# Altium schematic sheet Airlock_Power.SchDoc(Airlock_Power)
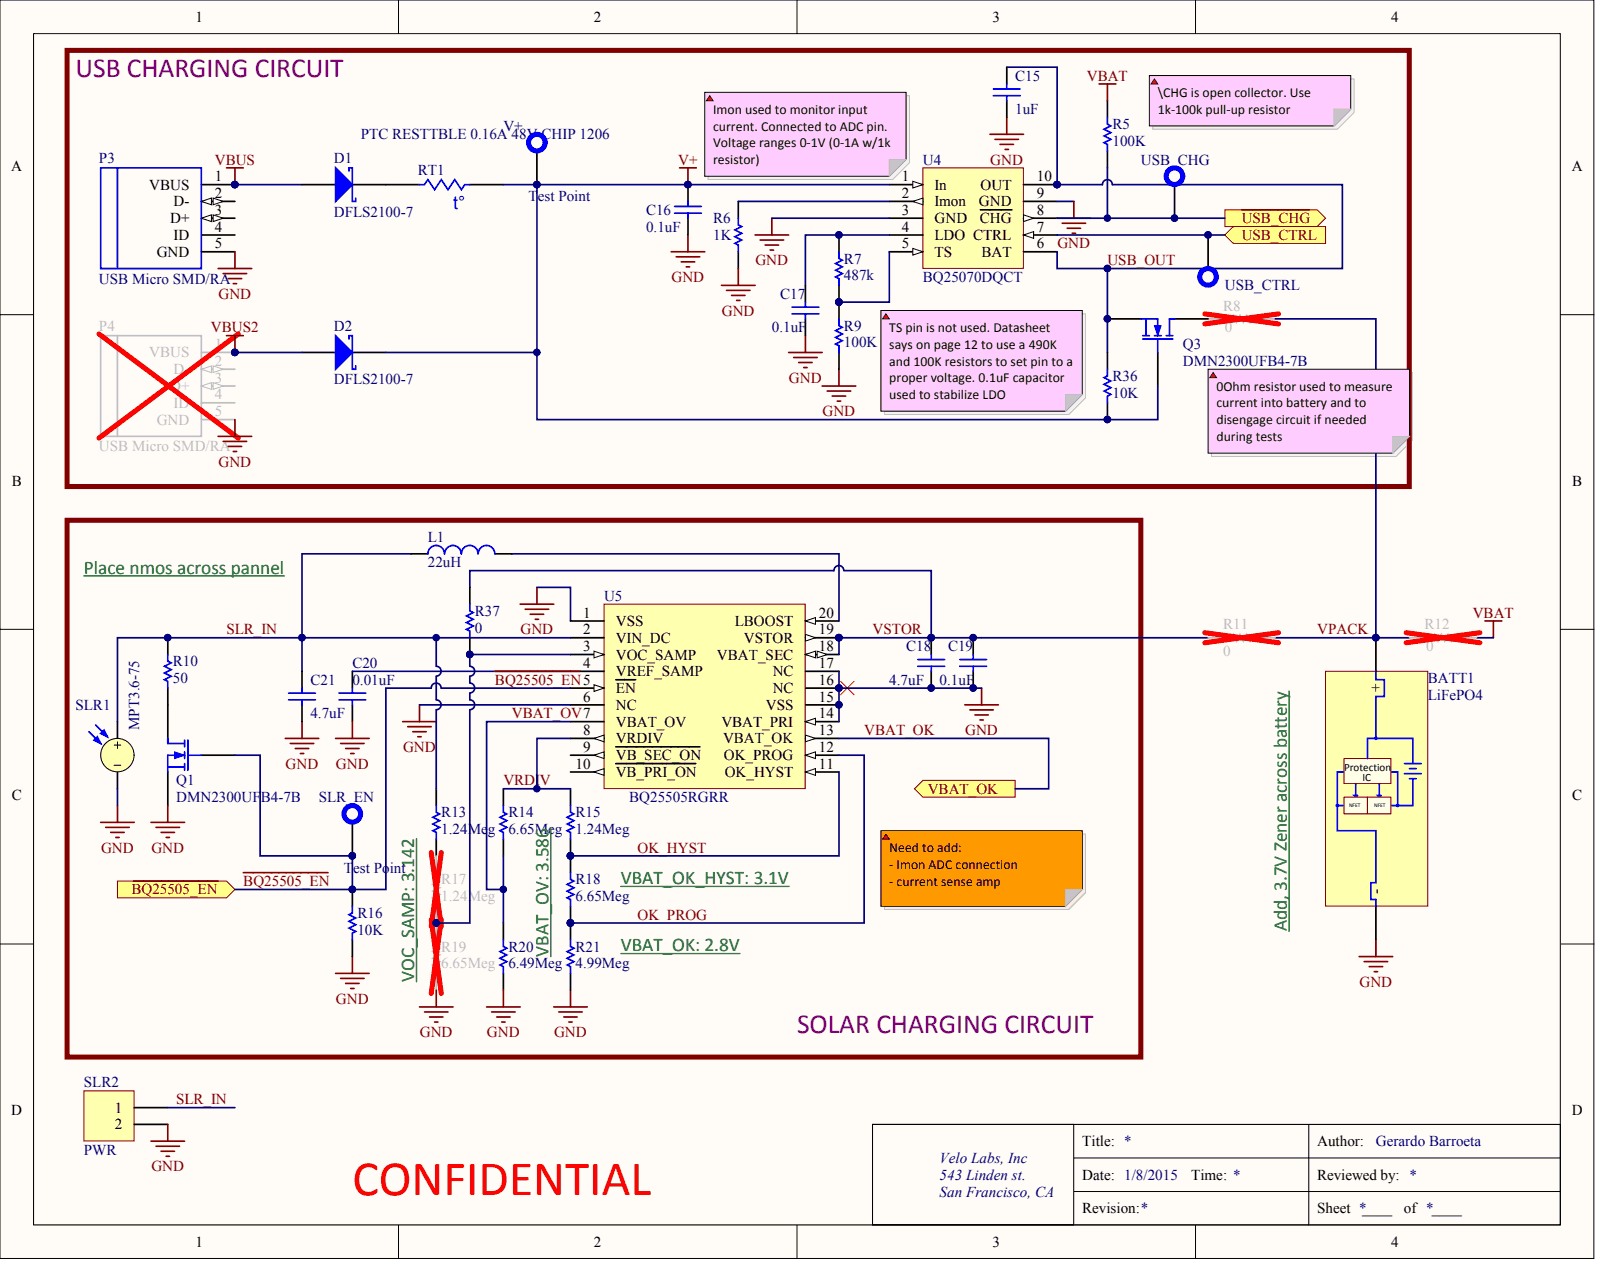
[diagram p0: Airlock_Power.SchDoc]

## Parts
BATT1  LiFePO4
C15  value 1uF  (hidden comment: X5R, 6.3V 10%)
C16  value 0.1uF  (hidden comment: X7R, 16V, 10%)
C17  value 0.1uF  (hidden comment: X7R, 16V, 10%)
C18  value 4.7uF  (hidden comment: X5R, 10V, 10%)
C19  value 0.1uF  (hidden comment: X7R, 16V, 10%)
C20  value 0.01uF  (hidden comment: X7R, 25V, 10%)
C21  value 4.7uF  (hidden comment: X5R, 10V, 10%)
D1  DFLS2100-7
D2  DFLS2100-7
L1  value 22uH  (hidden comment: Inductor)
P3  USB Micro SMD/RA
P4  USB Micro SMD/RA
Q1  DMN2300UFB4-7B
Q3  DMN2300UFB4-7B
R5  value 100K  (hidden comment: 5% 1/10W)
R6  1%  value 1K
R7  value 487k  (hidden comment: 1%)
R8  value 0  (hidden comment: Res1)
R9  value 100K  (hidden comment: 5% 1/10W)
R10  value 50  (hidden comment: 1%)
R11  value 0  (hidden comment: Res1)
R12  value 0  (hidden comment: Res1)
R13  1%  value 1.24Meg
R14  value 6.65Meg  (hidden comment: Res1)
R15  1%  value 1.24Meg
R16  1%  value 10K
R17  1%  value 1.24Meg
R18  value 6.65Meg  (hidden comment: Res1)
R19  value 6.65Meg  (hidden comment: Res1)
R20  value 6.49Meg  (hidden comment: 1%)
R21  value 4.99Meg  (hidden comment: 1%)
R36  1%  value 10K
R37  value 0  (hidden comment: Res1)
RT1  PTC RESTTBLE 0.16A 48V CHIP 1206
SLR1  MPT3.6-75
SLR2  PWR
SLR_EN  Test Point
U4  BQ25070DQCT
U5  BQ25505RGRR
USB_CHG  Test Point
USB_CTRL  Test Point
V+  Test Point

## Ports
USB_CTRL, VBAT_OK, ~{BQ25505_EN}, ~{USB_CHG}

## Nets
GND: BATT1.2 C15.1 C16.1 C17.1 C18.1 C19.1 C20.1 C21.1 P3.0 P3.5(GND) P4.0 P4.5(GND) Q1.2 R6.1 R9.2 R16.2 R19.1 R20.1 R21.1 SLR1.2 SLR2.2 U4.3(GND) U4.9(GND) U4.11 U5.1(VSS) U5.6(NC) U5.14(VBAT_PRI) U5.15(VSS) U5.16(NC) U5.17(NC) U5.21
V+: C16.2 D2.1 Q3.1 R36.2 RT1.1 U4.1(In) V+.1
VBAT: R5.2 R12.1
VBUS: D1.2 P3.1(VBUS)
VBUS2: D2.2 P4.1(VBUS)
~{BQ25505_EN}: Q1.1 R16.1 SLR_EN.1 U5.5(EN) ; port ~{BQ25505_EN}
~{USB_CHG}: R5.1 U4.8(CHG) USB_CHG.1 ; port ~{USB_CHG}
OK_HYST: R15.1 R18.2 U5.11(OK_HYST)
OK_PROG: R18.1 R21.2 U5.12(OK_PROG)
SLR_IN: C21.2 L1.1 R10.1 R13.2 SLR1.1 SLR2.1 U5.2(VIN_DC)
USB_CTRL: U4.7(CTRL) USB_CTRL.1 ; port USB_CTRL
USB_OUT: C15.2 Q3.2 R36.1 U4.6(BAT) U4.10(OUT)
VBAT_OK: U5.13(VBAT_OK) ; port VBAT_OK
VBAT_OV: R14.1 R20.2 U5.7(VBAT_OV)
VPACK: BATT1.1 R8.1 R11.2 R12.2
VRDIV: R14.2 R15.2 U5.8(VRDIV)
VSTOR: C18.2 C19.2 R11.1 R37.2 U5.18(VBAT_SEC) U5.19(VSTOR)
NetC17_2: C17.2 R7.1 U4.4(LDO)
NetC20_2: C20.2 U5.4(VREF_SAMP)
NetD1_1: D1.1 RT1.2
NetL1_2: L1.2 U5.20(LBOOST)
NetQ1_3: Q1.3 R10.2
NetQ3_3: Q3.3 R8.2
NetR6_2: R6.2 U4.2(Imon)
NetR7_2: R7.2 R9.1 U4.5(TS)
NetR13_1: R13.1 R17.2
NetR17_1: R17.1 R19.2 R37.1 U5.3(VOC_SAMP)

Not connected: P3 2(D-) 3(D+) 4(ID); P4 2(D-) 3(D+) 4(ID); U5 9(VB_SEC_ON) 10(VB_PRI_ON)

## Library data of the parts
C15 = (no symbol name): Manufacturer: Murata Electronics North America; Manufacturer Part Number: GRM155R60J105KE19D; Description 1: CAP CER 1UF 6.3V 10% X5R 0402; Capacitance: 1.0µF; Applications: General Purpose; Supplier 1: Digi-Key; Supplier Part Number 1: 490-1320-1-ND; RoHS: RoHS Compliant; Category: Capacitors; Stock 1: 2947181; Pricing 1: 1=0.1, 10=0.035, 50=0.0192, 100=0.0163, 250=0.01332, 500=0.01138, 1000=0.0087, 5000=0.00725 (USD); Packaging: Cut Tape (CT); Tolerance: ±10%; Voltage - Rated: 6.3V; Temperature Coefficient: X5R; Mounting Type: Surface Mount, MLCC; Operating Temperature: -55°C ~ 85°C; Ratings: -; Package / Case: 0402 (1005 Metric); Size / Dimension: 0.039" L x 0.020" W (1.00mm x 0.50mm); Height - Seated (Max): -; Thickness (Max): 0.022" (0.55mm); Lead Spacing: -; Features: -; Lead Style: -; ComponentLink1URL: http://www.murata.com/~/media/webrenewal/support/library/catalog/products/capacitor/mlcc/c02e.ashx; ComponentLink1Description: http://www.murata.com/~/media/webrenewal/support/library/catalog/products/capacitor/mlcc/c02e.ashx
C16 C17 C19 = (no symbol name): Manufacturer: Murata Electronics North America; Manufacturer Part Number: GRM155R71C104KA88D; Description 1: CAP CER 0.1UF 16V 10% X7R 0402; Capacitance: 0.1µF; Supplier 1: Digi-Key; Supplier Part Number 1: 490-3261-1-ND; RoHS: RoHS Compliant; Category: Capacitors; Stock 1: 16410340; Pricing 1: 1=0.1, 10=0.015, 50=0.0082, 100=0.0069, 250=0.00564, 500=0.00482, 1000=0.00375, 5000=0.00313 (USD); Packaging: Cut Tape (CT); Tolerance: ±10%; Voltage - Rated: 16V; Temperature Coefficient: X7R; Mounting Type: Surface Mount, MLCC; Operating Temperature: -55°C ~ 125°C; Applications: General Purpose; Ratings: -; Package / Case: 0402 (1005 Metric); Size / Dimension: 0.039" L x 0.020" W (1.00mm x 0.50mm); Height - Seated (Max): -; Thickness (Max): 0.022" (0.55mm); Lead Spacing: -; Features: -; Lead Style: -; ComponentLink1URL: http://www.murata.com/~/media/webrenewal/support/library/catalog/products/capacitor/mlcc/c02e.ashx; ComponentLink1Description: http://www.murata.com/~/media/webrenewal/support/library/catalog/products/capacitor/mlcc/c02e.ashx
C18 C21 = (no symbol name): Manufacturer: Taiyo Yuden; Manufacturer Part Number: LMK107BJ475KA-T; Description 1: CAP CER 4.7UF 10V 10% X5R 0603; Capacitance: 4.7µF; Supplier 1: Digi-Key; Supplier Part Number 1: 587-1441-2-ND; RoHS: RoHS Compliant; Category: Capacitors; Stock 1: 360000; Pricing 1: 4000=0.038, 8000=0.0361, 12000=0.0342, 28000=0.0323 (USD); Packaging: Tape & Reel (TR); Voltage - Rated: 10V; Tolerance: ±10%; Temperature Coefficient: X5R; Mounting Type: Surface Mount, MLCC; Operating Temperature: -55°C ~ 85°C; Applications: General Purpose; Ratings: -; Package / Case: 0603 (1608 Metric); Size / Dimension: 0.063" L x 0.031" W (1.60mm x 0.80mm); Height - Seated (Max): -; Thickness (Max): 0.035" (0.90mm); Lead Spacing: -; Features: -; Lead Style: -; ComponentLink1URL: http://www.yuden.co.jp/or/product/category/capacitor/LMK107BJ475KA-T.pdf; ComponentLink1Description: http://www.yuden.co.jp/or/product/category/capacitor/LMK107BJ475KA-T.pdf
C20 = (no symbol name): Manufacturer: Murata Electronics North America; Manufacturer Part Number: GRM155R71E103KA01D; Description 1: CAP CER 10000PF 25V 10% X7R 0402; Capacitance: 10000pF; Published: 8-Jun-2000; LatestRevisionDate: 29-May-2009; LatestRevisionNote: IPC-7351 Footprint Added.; PackageReference: C1206; PackageDescription: Chip Capacitor; Supplier 1: Digi-Key; Supplier Part Number 1: 490-1312-1-ND; RoHS: RoHS Compliant; Category: Capacitors; Stock 1: 1062157; Pricing 1: 1=0.1, 10=0.014, 50=0.0078, 100=0.0066, 250=0.0054, 500=0.00462, 1000=0.0036, 5000=0.003 (USD); Packaging: Cut Tape (CT); Voltage - Rated: 25V; Tolerance: ±10%; Temperature Coefficient: X7R; Mounting Type: Surface Mount, MLCC; Operating Temperature: -55°C ~ 125°C; Applications: General Purpose; Ratings: -; Package / Case: 0402 (1005 Metric); Size / Dimension: 0.039" L x 0.020" W (1.00mm x 0.50mm); Height - Seated (Max): -; Thickness (Max): 0.022" (0.55mm); Lead Spacing: -; Features: -; Lead Style: -; ComponentLink1URL: http://www.murata.com/products/catalog/pdf/c02e.pdf; ComponentLink1Description: http://www.murata.com/products/catalog/pdf/c02e.pdf; Publisher: Altium Limited
D1 D2 = (no symbol name): Manufacturer: Diodes Incorporated; Description 1: DIODE SCHOTKY 100V 2A POWERDI123; Diode Type: Schottky; Voltage - DC Reverse (Vr) (Max): 100V; Current - Average Rectified (Io): 2A; Voltage - Forward (Vf) (Max) @ If: 860mV @ 2A; Speed: Fast Recovery =< 500ns, > 200mA (Io); Current - Reverse Leakage @ Vr: 1µA @ 100V; Capacitance @ Vr, F: 36pF @ 5V, 1MHz; Supplier 1: Digi-Key; Manufacturer Part Number: DFLS2100-7; RoHS: RoHS Compliant; Category: Discrete Semiconductor Products; Stock 1: 21950; Pricing 1: 1=0.74, 10=0.65, 25=0.5744, 100=0.5004, 250=0.4356, 500=0.3708, 1000=0.297 (USD); Packaging: Cut Tape (CT); Reverse Recovery Time (trr): -; Mounting Type: Surface Mount; Package / Case: 2-SMD, Flat Lead; Supplier Device Package: PowerDI™ 123; Operating Temperature - Junction: -55°C ~ 175°C; ComponentLink1URL: http://www.diodes.com/datasheets/ds31475.pdf; ComponentLink1Description: http://www.diodes.com/datasheets/ds31475.pdf; Supplier Part Number 1: DFLS2100-7DICT-ND
L1 = (no symbol name): Manufacturer: Coilcraft; Manufacturer Part Number: LPS4018-223MRC; Code_IEC: 1005; Description 1: Fixed Inductors 22 uH 0.9 A 20% SMD Shielded; Core Material: Ferrite; Inductance: 22 uH; Maximum DC Current: 830 mA; Maximum DC Resistance: 360 mOhms; Self Resonant Frequency: 26 MHz; Shielding: Shielded; Tolerance: 20 %; Type: Shielded Power Inductor; Case Height: 1.7 mm; Case Length: 4 mm; Test Frequency: 100 kHz; Product Status: New Product; Published: 8-Jun-2000; LatestRevisionDate: 29-May-2009; LatestRevisionNote: IPC-7351 Footprint Added.; PackageReference: 0402-A; PackageDescription: Chip Inductor; Code_JEITA: 0402; Supplier 1: Mouser; Supplier Part Number 1: 994-LPS4018-223MRC; RoHS: RoHS Compliant; Category: Fixed Inductors; Stock 1: 7000; Pricing 1: 1000=0.684, 2000=0.637 (AUD); Operating Temperature Range: - 40 C to + 85 C; Packaging: Reel; Product: Power Inductors; Series: LPS4018; Termination Style: SMD/SMT; Brand: Coilcraft; Case Width: 4 mm; RoHS - Mouser: Y; Minimum Order Quantity: 1000; Reeling: True; ComponentLink1URL: http://www.coilcraft.com/lps4018.cfm; ComponentLink1Description: http://www.coilcraft.com/lps4018.cfm; Publisher: Altium Limited
P3 P4 = (no symbol name): Manufacturer: FCI; Manufacturer Part Number: 10104111-0001LF; Description 1: CONN RCPT STD MICRO USB TYPE AB; Connector Type: USB - micro AB; Number of Contacts: 5; Gender: Receptacle; Specifications: USB 2.0; Supplier 1: Digi-Key; RoHS: RoHS Compliant; Category: Connectors, Interconnects; Stock 1: 13009; Pricing 1: 1=0.79, 10=0.742, 25=0.6676, 50=0.5934, 100=0.5687, 250=0.51928, 500=0.49456 (USD); Packaging: Cut Tape (CT); Mounting Type: Surface Mount, Right Angle, Horizontal; Features: -; Ingress Protection: -; ComponentLink1URL: http://portal.fciconnect.com/Comergent//fci/drawing/10104111.pdf; ComponentLink1Description: http://portal.fciconnect.com/Comergent//fci/drawing/10104111.pdf; Supplier Part Number 1: 609-4053-1-ND
Q1 Q3 = (no symbol name): Manufacturer: Diodes Inc; Description 1: MOSF N CH 20V 1.3A DFN1006H4-3; FET Type: MOSFET N-Channel, Metal Oxide; FET Feature: Logic Level Gate; Drain to Source Voltage (Vdss): 20V; Current - Continuous Drain (Id) @ 25° C: 1.3A (Ta); Rds On (Max) @ Id, Vgs: 175 mOhm @ 300mA, 4.5V; Vgs(th) (Max) @ Id: 950mV @ 250µA; Gate Charge (Qg) @ Vgs: 1.6nC @ 4.5V; Input Capacitance (Ciss) @ Vds: 64.3pF @ 25V; Power - Max: 470mW; Supplier 1: Digi-Key; Manufacturer Part Number: DMN2300UFB4-7B; RoHS: RoHS Compliant; Category: Discrete Semiconductor Products; Stock 1: 61054; Pricing 1: 1=0.48, 10=0.348, 25=0.2704, 100=0.2048, 250=0.14476, 500=0.11588, 1000=0.08888, 2500=0.07725, 5000=0.06938 (USD); Packaging: Digi-Reel®; Mounting Type: Surface Mount; Package / Case: 3-XFDFN; Supplier Device Package: 3-DFN1006H4 (1.0x0.6); ComponentLink1URL: http://www.diodes.com/datasheets/DMN2300UFB4.pdf; ComponentLink1Description: http://www.diodes.com/datasheets/DMN2300UFB4.pdf; Supplier Part Number 1: DMN2300UFB4-7BDIDKR-ND
R5 R9 = (no symbol name): Manufacturer: Panasonic Electronic Components; Manufacturer Part Number: ERJ-2GEJ104X; Description 1: RES 100K OHM 1/10W 5% 0402 SMD; Power (Watts): 0.1W, 1/10W; Temperature Coefficient: ±200ppm/°C; Published: 8-Jun-2000; LatestRevisionDate: 17-Jul-2002; LatestRevisionNote: Re-released for DXP Platform.; PackageReference: AXIAL-0.3; PackageDescription: Axial Device, Thru-Hole; 2 Leads; 0.3 in Pin Spacing; Supplier 1: Digi-Key; Supplier Part Number 1: P100KJCT-ND; RoHS: RoHS Compliant; Category: Resistors; Stock 1: 539799; Pricing 1: 1=0.1, 50=0.0172, 100=0.0131, 250=0.00976, 500=0.0072, 1000=0.0048, 5000=0.00338 (USD); Packaging: Cut Tape (CT); Resistance (Ohms): 100k; Tolerance: ±5%; Composition: Thick Film; Features: -; Package / Case: 0402 (1005 Metric); Supplier Device Package: 0402; Size / Dimension: 0.039" L x 0.020" W (1.00mm x 0.50mm); Height: 0.016" (0.40mm); Number of Terminations: 2; ComponentLink1URL: http://industrial.panasonic.com/www-cgi/jvcr13pz.cgi?E+PZ+3+AOA0001+ERJ2GEJ104X+7+WW; ComponentLink1Description: http://industrial.panasonic.com/www-cgi/jvcr13pz.cgi?E+PZ+3+AOA0001+ERJ2GEJ104X+7+WW; Publisher: Altium Limited
R6 = (no symbol name): Manufacturer: Panasonic Electronic Components; Manufacturer Part Number: ERJ-2RKF1001X; Description 1: RES 1K OHM 1/10W 1% 0402 SMD; Power (Watts): 0.1W, 1/10W; Temperature Coefficient: ±100ppm/°C; Published: 8-Jun-2000; LatestRevisionDate: 17-Jul-2002; LatestRevisionNote: Re-released for DXP Platform.; PackageReference: AXIAL-0.3; PackageDescription: Axial Device, Thru-Hole; 2 Leads; 0.3 in Pin Spacing; Supplier 1: Digi-Key; Supplier Part Number 1: P1.00KLCT-ND; RoHS: RoHS Compliant; Category: Resistors; Stock 1: 5044564; Pricing 1: 1=0.1, 50=0.0196, 100=0.0149, 250=0.01104, 500=0.00816, 1000=0.00544, 5000=0.00383 (USD); Packaging: Cut Tape (CT); Resistance (Ohms): 1k; Tolerance: ±1%; Composition: Thick Film; Features: -; Package / Case: 0402 (1005 Metric); Supplier Device Package: 0402; Size / Dimension: 0.039" L x 0.020" W (1.00mm x 0.50mm); Height: 0.016" (0.40mm); Number of Terminations: 2; ComponentLink1URL: http://industrial.panasonic.com/www-cgi/jvcr13pz.cgi?E+PZ+3+AOA0002+ERJ2RKF1001X+7+WW; ComponentLink1Description: http://industrial.panasonic.com/www-cgi/jvcr13pz.cgi?E+PZ+3+AOA0002+ERJ2RKF1001X+7+WW; Operating Temperature: -55°C ~ 155°C; Publisher: Altium Limited
R7 = (no symbol name): Manufacturer: Panasonic Electronic Components; Manufacturer Part Number: ERJ-2RKF4873X; Description 1: RES 487K OHM 1/10W 1% 0402 SMD; Power (Watts): 0.1W, 1/10W; Temperature Coefficient: ±100ppm/°C; Supplier 1: Digi-Key; Supplier Part Number 1: P487KLCT-ND; RoHS: RoHS Compliant; Category: Resistors; Stock 1: 15470; Pricing 1: 1=0.1, 50=0.0196, 100=0.0149, 250=0.01104, 500=0.00816, 1000=0.00544, 5000=0.00383 (USD); Packaging: Cut Tape (CT); Resistance (Ohms): 487k; Tolerance: ±1%; Composition: Thick Film; Features: -; Package / Case: 0402 (1005 Metric); Supplier Device Package: 0402; Size / Dimension: 0.039" L x 0.020" W (1.00mm x 0.50mm); Height: 0.016" (0.40mm); Number of Terminations: 2; ComponentLink1URL: http://industrial.panasonic.com/www-cgi/jvcr13pz.cgi?E+PZ+3+AOA0002+ERJ2RKF4873X+7+WW; ComponentLink1Description: http://industrial.panasonic.com/www-cgi/jvcr13pz.cgi?E+PZ+3+AOA0002+ERJ2RKF4873X+7+WW
R8 R11 R12 R37 = (no symbol name): Manufacturer: Panasonic Electronic Components; Manufacturer Part Number: ERJ-3GEY0R00V; Description 1: RES SMD 0.0 OHM JUMPER 1/10W; Resistance (Ohms): 0.0; Tolerance: Jumper; Power (Watts): 0.1W, 1/10W; Published: 8-Jun-2000; LatestRevisionDate: 17-Jul-2002; LatestRevisionNote: Re-released for DXP Platform.; PackageReference: AXIAL-0.3; PackageDescription: Axial Device, Thru-Hole; 2 Leads; 0.3 in Pin Spacing; Supplier 1: Digi-Key; Supplier Part Number 1: P0.0GCT-ND; RoHS: RoHS Compliant; Category: Resistors; Stock 1: 6006949; Pricing 1: 1=0.1, 50=0.0126, 100=0.0096, 250=0.00716, 500=0.00528, 1000=0.00352, 2500=0.00297 (USD); Packaging: Cut Tape (CT); Composition: Thick Film; Features: Automotive AEC-Q200 Qualified; Temperature Coefficient: -; Operating Temperature: -55°C ~ 155°C; Package / Case: 0603 (1608 Metric); Supplier Device Package: 0603; Size / Dimension: 0.063" L x 0.031" W (1.60mm x 0.80mm); Height: 0.022" (0.55mm); Number of Terminations: 2; ComponentLink1URL: http://industrial.panasonic.com/www-cgi/jvcr13pz.cgi?E+PZ+3+AOA0001+ERJ3GEY0R00V+7+WW; ComponentLink1Description: http://industrial.panasonic.com/www-cgi/jvcr13pz.cgi?E+PZ+3+AOA0001+ERJ3GEY0R00V+7+WW; Publisher: Altium Limited
R10 = (no symbol name): Manufacturer: Panasonic Electronic Components; Manufacturer Part Number: ERJ-8ENF51R1V; Description 1: RES SMD 51.1 OHM 1% 1/4W 1206; Resistance (Ohms): 51.1; Power (Watts): 0.25W, 1/4W; Temperature Coefficient: ±100ppm/°C; Supplier 1: Digi-Key; Supplier Part Number 1: P51.1FCT-ND; RoHS: RoHS Compliant; Category: Resistors; Stock 1: 62699; Pricing 1: 1=0.1, 50=0.0368, 100=0.028, 250=0.0208, 500=0.01536, 1000=0.01024 (USD); Packaging: Cut Tape (CT); Tolerance: ±1%; Composition: Thick Film; Features: Automotive AEC-Q200 Qualified; Operating Temperature: -55°C ~ 155°C; Package / Case: 1206 (3216 Metric); Supplier Device Package: 1206; Size / Dimension: 0.126" L x 0.063" W (3.20mm x 1.60mm); Height: 0.028" (0.70mm); Number of Terminations: 2; ComponentLink1URL: http://industrial.panasonic.com/www-cgi/jvcr13pz.cgi?E+PZ+3+AOA0002+ERJ8ENF51R1V+7+WW; ComponentLink1Description: http://industrial.panasonic.com/www-cgi/jvcr13pz.cgi?E+PZ+3+AOA0002+ERJ8ENF51R1V+7+WW
R13 R15 R17 = (no symbol name): Manufacturer: Vishay Dale; Manufacturer Part Number: CRCW04021M24FKED; Description 1: RES 1.24M OHM 1/16W 1% 0402 SMD; Resistance (Ohms): 1.24M; Power (Watts): 0.063W, 1/16W; Temperature Coefficient: ±100ppm/°C; Supplier 1: Digi-Key; Supplier Part Number 1: 541-1.24MLDKR-ND; RoHS: RoHS Compliant; Category: Resistors; Stock 1: 8506; Pricing 1: 10=0.083, 50=0.0444, 200=0.0255, 1000=0.0174 (USD); Packaging: Digi-Reel®; Tolerance: ±1%; Composition: Thick Film; Features: Automotive AEC-Q200 Qualified; Package / Case: 0402 (1005 Metric); Supplier Device Package: 0402 (1005 Metric); Size / Dimension: 0.039" L x 0.020" W (1.00mm x 0.50mm); Height: 0.016" (0.40mm); Number of Terminations: 2; ComponentLink1URL: http://www.vishay.com/doc?20035; ComponentLink1Description: http://www.vishay.com/doc?20035
R14 R18 R19 = (no symbol name): Manufacturer: Vishay Dale; Manufacturer Part Number: CRCW06036M65FKEA; Description 1: RES 6.65M OHM 1/10W 1% 0603 SMD; Resistance (Ohms): 6.65M; Tolerance: ±1%; Power (Watts): 0.1W, 1/10W; Temperature Coefficient: ±100ppm/°C; Supplier 1: Digi-Key; Supplier Part Number 1: 541-6.65MHDKR-ND; RoHS: RoHS Compliant; Category: Resistors; Stock 1: 15342; Pricing 1: 10=0.081, 50=0.0436, 200=0.0251, 1000=0.01711 (USD); Packaging: Digi-Reel®; Composition: Thick Film; Features: Automotive AEC-Q200 Qualified; Package / Case: 0603 (1608 Metric); Supplier Device Package: 0603 (1608 Metric); Size / Dimension: 0.061" L x 0.033" W (1.55mm x 0.85mm); Height: 0.020" (0.50mm); Number of Terminations: 2; ComponentLink1URL: http://www.vishay.com/doc?20035; ComponentLink1Description: http://www.vishay.com/doc?20035
R16 R36 = (no symbol name): Manufacturer: Panasonic Electronic Components; Manufacturer Part Number: ERJ-2RKF1002X; Description 1: RES SMD 10K OHM 1% 1/10W 0402; Power (Watts): 0.1W, 1/10W; Temperature Coefficient: ±100ppm/°C; Published: 8-Jun-2000; LatestRevisionDate: 17-Jul-2002; LatestRevisionNote: Re-released for DXP Platform.; PackageReference: AXIAL-0.3; PackageDescription: Axial Device, Thru-Hole; 2 Leads; 0.3 in Pin Spacing; Supplier 1: Digi-Key; Supplier Part Number 1: P10.0KLCT-ND; RoHS: RoHS Compliant; Category: Resistors; Stock 1: 185308; Pricing 1: 1=0.1, 50=0.0196, 100=0.0149, 250=0.01104, 500=0.00816, 1000=0.00544, 5000=0.00383 (USD); Packaging: Cut Tape (CT); Resistance (Ohms): 10k; Tolerance: ±1%; Composition: Thick Film; Features: Automotive AEC-Q200 Qualified; Operating Temperature: -55°C ~ 155°C; Package / Case: 0402 (1005 Metric); Supplier Device Package: 0402; Size / Dimension: 0.039" L x 0.020" W (1.00mm x 0.50mm); Height: 0.016" (0.40mm); Number of Terminations: 2; ComponentLink1URL: http://industrial.panasonic.com/www-cgi/jvcr13pz.cgi?E+PZ+3+AOA0002+ERJ2RKF1002X+7+WW; ComponentLink1Description: http://industrial.panasonic.com/www-cgi/jvcr13pz.cgi?E+PZ+3+AOA0002+ERJ2RKF1002X+7+WW; Publisher: Altium Limited
R20 = (no symbol name): Manufacturer: Stackpole Electronics Inc; Manufacturer Part Number: RMCF0402FT6M49; Description 1: RES 6.49M OHM 1/16W 1% 0402; Resistance (Ohms): 6.49M; Power (Watts): 0.063W, 1/16W; Temperature Coefficient: ±200ppm/°C; Supplier 1: Digi-Key; Supplier Part Number 1: RMCF0402FT6M49TR-ND; RoHS: RoHS Compliant; Category: Resistors; Stock 1: 10000; Pricing 1: 10000=0.00198, 30000=0.00174, 50000=0.0016, 100000=0.00156 (USD); Packaging: Tape & Reel (TR); Tolerance: ±1%; Composition: Thick Film; Features: -; Package / Case: 0402 (1005 Metric); Supplier Device Package: 0402; Size / Dimension: 0.039" L x 0.020" W (1.00mm x 0.50mm); Height: 0.016" (0.40mm); Number of Terminations: 2; ComponentLink1URL: http://www.seielect.com/Catalog/SEI-RMCF_RMCP.pdf; ComponentLink1Description: http://www.seielect.com/Catalog/SEI-RMCF_RMCP.pdf
R21 = (no symbol name): Manufacturer: Vishay Dale; Manufacturer Part Number: CRCW04024M99FKED; Description 1: RES 4.99M OHM 1/16W 1% 0402 SMD; Resistance (Ohms): 4.99M; Power (Watts): 0.063W, 1/16W; Temperature Coefficient: ±100ppm/°C; Supplier 1: Digi-Key; Supplier Part Number 1: 541-4.99MLDKR-ND; RoHS: RoHS Compliant; Category: Resistors; Stock 1: 100845; Pricing 1: 10=0.083, 50=0.0444, 200=0.0255, 1000=0.0174 (USD); Packaging: Digi-Reel®; Tolerance: ±1%; Composition: Thick Film; Features: Automotive AEC-Q200 Qualified; Package / Case: 0402 (1005 Metric); Supplier Device Package: 0402 (1005 Metric); Size / Dimension: 0.039" L x 0.020" W (1.00mm x 0.50mm); Height: 0.016" (0.40mm); Number of Terminations: 2; ComponentLink1URL: http://www.vishay.com/doc?20035; ComponentLink1Description: http://www.vishay.com/doc?20035
RT1 = (no symbol name): Manufacturer: Bel Fuse Inc; Manufacturer Part Number: 0ZCJ0016FF2E; Current - Max: 10A; Current - Hold (Ih) (Max): 160mA; Current - Trip (It): 450mA; R Min/Max: 1.100 ~ 5.000 Ohm; Time to Trip: 0.3s; Supplier 1: Digi-Key; Supplier Part Number 1: 507-1796-1-ND; RoHS: RoHS Compliant; Description 1: PTC RESTTBLE 0.16A 48V CHIP 1206; Category: Circuit Protection; Stock 1: 9154; Pricing 1: 1=0.12, 10=0.107, 25=0.0992, 100=0.0891, 250=0.0794, 500=0.06616, 1000=0.06269 (USD); Packaging: Cut Tape (CT); Voltage - Max: 48V; Package / Case: 1206 (3216 Metric), Concave; ComponentLink1URL: http://www.belfuse.com/pdfs/0ZCJ.pdf; ComponentLink1Description: http://www.belfuse.com/pdfs/0ZCJ.pdf
U4 = (no symbol name): Manufacturer: Texas Instruments; Description 1: IC LINEAR BATT CHRGR SGL 10WSON; Function: Charge Management; Battery Chemistry: LiFePO4; Voltage - Supply: 3.75 V ~ 28 V; Supplier 1: Digi-Key; Manufacturer Part Number: BQ25070DQCT; RoHS: RoHS Compliant; Category: Integrated Circuits (ICs); Stock 1: 2418; Pricing 1: 1=2.58, 10=2.333, 25=2.09, 100=1.8792 (USD); Packaging: Cut Tape (CT); Operating Temperature: -40°C ~ 85°C; Mounting Type: Surface Mount; Package / Case: 10-WFDFN Exposed Pad; Supplier Device Package: 10-WSON (3x2); ComponentLink1URL: http://www.ti.com/lit/ds/symlink/bq25070.pdf; ComponentLink1Description: http://www.ti.com/lit/ds/symlink/bq25070.pdf; Supplier Part Number 1: 296-29180-1-ND
U5 = (no symbol name): Manufacturer: Texas Instruments; Description 1: IC ENERGY HARV CTRLR BATT 16QFN; Current - Supply: 325nA; Voltage - Supply: 0.12 V ~ 4 V; Supplier 1: Digi-Key; Manufacturer Part Number: BQ25505RGRR; RoHS: RoHS Compliant; Category: Integrated Circuits (ICs); Stock 1: 2849; Pricing 1: 1=6.91, 10=6.192, 25=5.568, 100=5.064, 250=4.584, 500=4.104, 750=3.72, 1000=3.456 (USD); Packaging: Cut Tape (CT); Applications: Energy Harvesting; Operating Temperature: -40°C ~ 85°C; Mounting Type: Surface Mount; Package / Case: 20-VFQFN Exposed Pad; Supplier Device Package: 20-VQFN (3.5x3.5); ComponentLink1URL: http://www.ti.com/lit/ds/symlink/bq25505.pdf; ComponentLink1Description: http://www.ti.com/lit/ds/symlink/bq25505.pdf; Supplier Part Number 1: 296-37078-1-ND
BATT1 SLR1 SLR2 SLR_EN USB_CHG USB_CTRL V+ = (no symbol name)
  BATT1: URL: http://www.alibaba.com/product-gs/1278893094/3_7v_180mah_lipo_battery_601235.html
  SLR2: LatestRevisionDate: 17-Jul-2002; LatestRevisionNote: Re-released for DXP Platform.; Publisher: Altium Limited
